# Altium schematic sheet output_driver.SchDoc
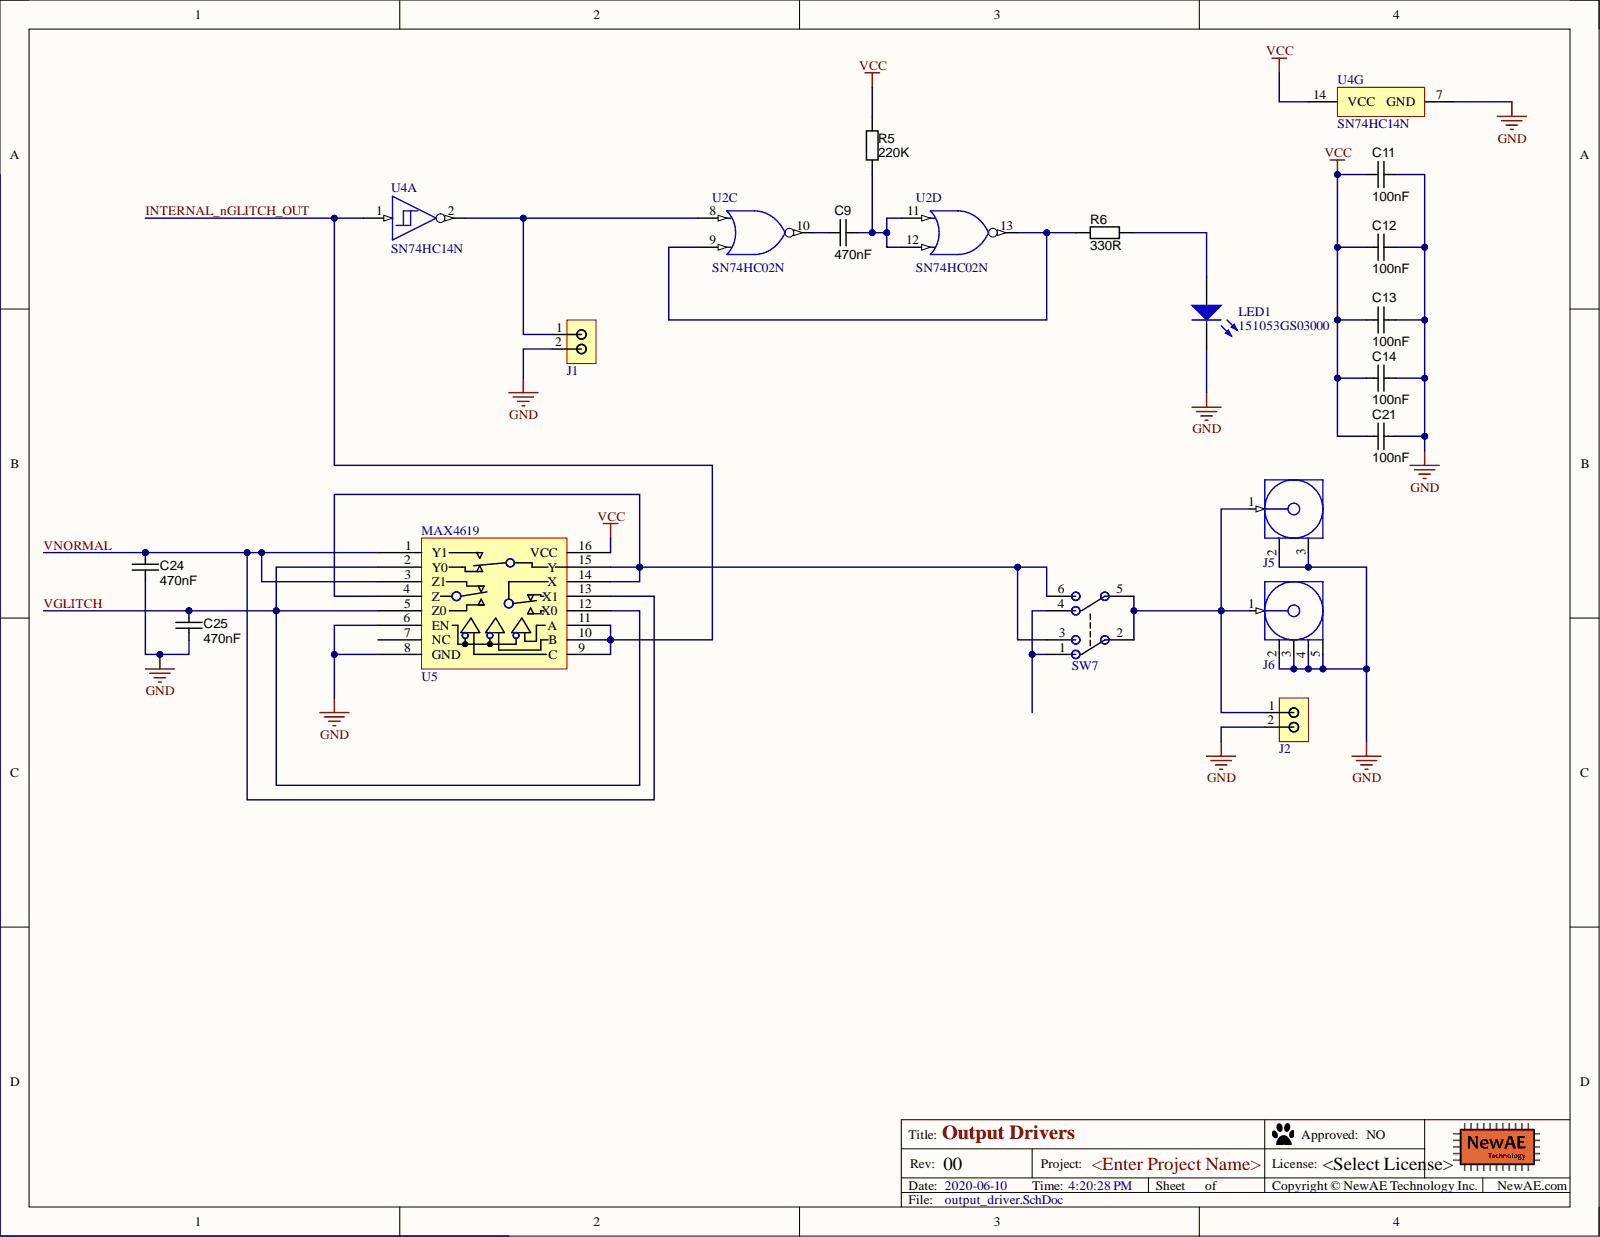
[diagram p0: output_driver.SchDoc]

## Parts
C9  value 470nF  [CAPC500P450250X550]  (hidden comment: approved sub OK)
C11  value 100nF  [CAPC500P450250X550]  (hidden comment: approved sub OK)
C12  value 100nF  [CAPC500P450250X550]  (hidden comment: approved sub OK)
C13  value 100nF  [CAPC500P450250X550]  (hidden comment: approved sub OK)
C14  value 100nF  [CAPC500P450250X550]  (hidden comment: approved sub OK)
C21  value 100nF  [CAPC500P450250X550]  (hidden comment: approved sub OK)
C24  value 470nF  [CAPC500P450250X550]  (hidden comment: approved sub OK)
C25  value 470nF  [CAPC500P450250X550]  (hidden comment: approved sub OK)
J1  Jumper  [2x2.54mm_Header]  (value not drawn)
J2  Jumper  [2x2.54mm_Header]  (value not drawn)
J5  73171-3150  [73171-3150]  (value not drawn)
J6  CON-SMA-PCBEDGE-003.062  [CON-SMAM-PCB-EDGE062]  (value not drawn)
LED1  151053GS03000  [Type 5mm]
R5  value 220K  [RESTH_AXIAL-0.4]  (hidden comment: Carbon Film)
R6  value 330R  [RESTH_AXIAL-0.4]  (hidden comment: Carbon Film)
SW7  EG2207  [EG2207]  (value not drawn)
U2  SN74HC02N  [N0014A]  (parts C,D)
U4  SN74HC14N  [N0014A]  (parts A,G)
U5  MAX4619  [DIP16]

## Nets
GND: C11.1 C12.1 C13.1 C14.1 C21.1 C24.1 C25.1 J1.2 J2.2 J5.2 J5.3 J6.2 J6.3 J6.4 J6.5 LED1.1 U4.7(GND) U5.6(EN) U5.8(GND)
VCC: C11.2 C12.2 C13.2 C14.2 C21.2 R5.2 U4.14(VCC) U5.16(VCC)
INTERNAL_nGLITCH_OUT: U4.1 U5.9(C) U5.10(B) U5.11(A)
VGLITCH: C25.2 U5.2(Y0) U5.5(Z0) U5.12(X0)
VNORMAL: C24.2 U5.1(Y1) U5.3(Z1) U5.13(X1)
NetC9_1: C9.1 U2.10
NetC9_2: C9.2 R5.1 U2.11 U2.12
NetJ1_1: J1.1 U2.8 U4.2
NetJ2_1: J2.1 J5.1 J6.1 SW7.2 SW7.5
NetLED1_2: LED1.2 R6.1
NetR6_2: R6.2 U2.9 U2.13
NetSW7_1: SW7.1 SW7.4
NetSW7_3: SW7.3 SW7.6 U5.4(Z) U5.14(X) U5.15(Y)

Not connected: U5 7(NC)

## Library data of the parts
C9 C24 C25 = CAPR_220n_Radial_5mm: Capacitor Ceramic 100nF 10% 50V X7R radial 5mm lead spacing; Manufacturer 1: TDK Corporation; Ratings: 50V; X7R; SCDType: spec; Component Kind: Standard; ComponentLink1Description: e4941_fk datasheet; ComponentLink1URL: http://www.tdk.co.jp/tefe02/e4941_fk.pdf; Library Name: PBL - Capacitors.SCHLIB; Material: ceramic; Operating Temperature: -55°C ~ 125°C; Package / Case: 0.217" L x 0.177" W (5.50mm x 4.50mm) radial 5mm LS; Supplier 1: Digi-Key; Tolerance: ±10%
C11-C14 C21 = CAPR_220n_Radial_5mm: Capacitor Ceramic 220nF 10% 50V X7R radial 5mm lead spacing; Manufacturer 1: TDK Corporation; Ratings: 50V; X7R; SCDType: spec; Component Kind: Standard; ComponentLink1Description: e4941_fk datasheet; ComponentLink1URL: http://www.tdk.co.jp/tefe02/e4941_fk.pdf; Library Name: PBL - Capacitors.SCHLIB; Material: ceramic; Operating Temperature: -55°C ~ 125°C; Package / Case: 0.217" L x 0.177" W (5.50mm x 4.50mm) radial 5mm LS; Supplier 1: Digi-Key; Tolerance: ±10%
J1 J2 = 2_PIN_JUMPER: 1x2 2.54mm header, male pins; Manufacture 1: Harwin; Manufacture Part Number 1: M20-9990246; Component Group: PCB Feature; Database Table Name: main; PP Material Stack: 0; Component Kind: Standard; Component Type: Standard; Library Name: NewAE_AltiumLib.DBLib; Mounting Technology: Through-hole; Part Number: 2_PIN_JUMPER; Pin Count: 2; PP Rotation: 0; RoHS: Yes; Supplier 1: Digi-Key; Supplier Part Number 1: 952-2262-ND
J5 = CON-BNC-CHEAP: Molex Cheap R/A BNC; Component Group: Connector; Database Table Name: main; PP Material Stack: 0; Component Kind: Standard; Component Type: Standard; Library Name: NewAE_AltiumLib.DBLib; Manufacture 1: Molex; Manufacture Part Number 1: 73171-3150; Part Number: CON-BNC-CHEAP; Pin Count: 3; PP Rotation: 0; Supplier 1: Digi-Key
J6 = CON-SMA-PCBEDGE-003.062: SMA Female Edge Mount Connector for 0.062" Thick Board; Database Table Name: main; Component Group: Connector; Component Kind: Standard; Component Type: Standard; ComponentLink1Description: Manufacturer URL; ComponentLink1URL: http://www.linxtechnologies.com/; ComponentLink2Description: Datasheet; ComponentLink2URL: http://www.connectorcity.com/diagrams/SMA/CONSMA003.062.pdf; Library Name: NewAE_AltiumLib.DBLib; Part Number: CON-SMA-PCBEDGE-003.062; Pin Count: 5
LED1 = CMP-1489-00005-1: THT LED round mono-color waterclear, WL-TMRW, Green; Manufacturer: Wuerth Elektronik; Component Kind: Standard; ComponentLink1Description: Manufacturer URL; ComponentLink1URL: http://www.we-online.com; ComponentLink2Description: Datasheet; ComponentLink2URL: http://katalog.we-online.de/pbs/datasheet/7448042001.pdfhttp://katalog.we-online.de/pbs/datasheet/7448042001.pdfhttp://katalog.we-online.de/pbs/datasheet/7448042001.pdfhttp://katalog.we-online.de/pbs/datasheet/151053GS03000.pdf; Emitting color: Green; Library Name: Altium Content Vault; PackageReference: Type 5mm; PartNumber: 151053GS03000
R5 R6 = RES_220R_Axial_0.25W_5%: Resistor THD Axial 10K Ohm 0.25W 5% Carbon Film; Manufacturer 1: Yageo; Manufacturer Part Number 1: CFR-25JB-220R; Ratings: 1/4W; SCDType: spec; Component Kind: Standard; Library Name: PBL - Resistors.SCHLIB; Package / Case: Axial; Supplier 1: Digi-Key; Supplier Part Number 1: 220QBK-ND; Tolerance: ±5%
SW7 = EG2207: DPDT Switch with 2 MH; Manufacture 1: E-Switch; Database Table Name: main; PP Material Stack: 0; Component Group: Switch; Component Kind: Standard; Component Type: Standard; Library Name: NewAE_AltiumLib.DBLib; Manufacture Part Number 1: EG2207; Mounting Technology: Through-hole; Part Number: EG2207; Pin Count: 8; PP Rotation: 0; RoHS: Yes; Supplier 1: Digi-Key; Supplier Part Number 1: EG1940-ND
U2 = CMP-0806-00198-3: Quadruple 2-Input Positive-NOR Gates, N0014A, TUBE; Manufacturer: Texas Instruments; Bits(#): 4; F @ Nom Voltage(Max)(Mhz): 70; ICC @ Nom Voltage(Max)(mA): 0.02; Input Type: CMOS; Output Drive (IOL/IOH)(Max)(mA): 4/-4; Rating: Catalog; tpd @ Nom Voltage(Max)(ns): 23; VCC(Max)(V): 6; VCC(Min)(V): 2; Voltage(Nom)(V): 5; Code_JEDEC: MS-001; Component Kind: Standard; DatasheetVersion: SCLS076E; Generic Part Number: SN74HC02; Library Name: Altium Content Vault; Operating Temperature Range(C): -40 to 85; Output Type: CMOS; PackageReference: N0014A; PackageVersion: http://www.ti.com/lit/ml/mpdi002c/mpdi002c.pdf
U4 = CMP-1615-00889-3: Hex Schmitt-Trigger Inverters, N0014A, TUBE; Manufacturer: Texas Instruments; Bits(#): 6; F @ Nom Voltage(Max)(Mhz): 20; ICC @ Nom Voltage(Max)(mA): 0.02; Input Type: CMOS; Output Drive (IOL/IOH)(Max)(mA): -4/4; Rating: Catalog; Schmitt Trigger: Yes; Technology Family: HC; tpd @ Nom Voltage(Max)(ns): 31; VCC(Min)(V): 2; Voltage(Nom)(V): 5; Code_JEDEC: MS-001; Component Kind: Standard; DatasheetVersion: SCLS085G; Generic Part Number: SN74HC14; Library Name: Altium Content Vault; Operating Temperature Range(C): -40 to 85; Output Type: CMOS; PackageReference: N0014A; VCC(Max)(V): 6
U5 = MAX4619: Analog Mux; Manufacture 1: Maxim; Component Group: Analog IC; Database Table Name: main; PP Material Stack: 0; Component Kind: Standard; Component Type: Standard; Library Name: NewAE_AltiumLib.DBLib; Manufacture Part Number 1: MAX4619; Part Number: MAX4619; Pin Count: 16; PP Rotation: 0
